# Altium schematic sheet 03_PAB.SchDoc(03_PAB)
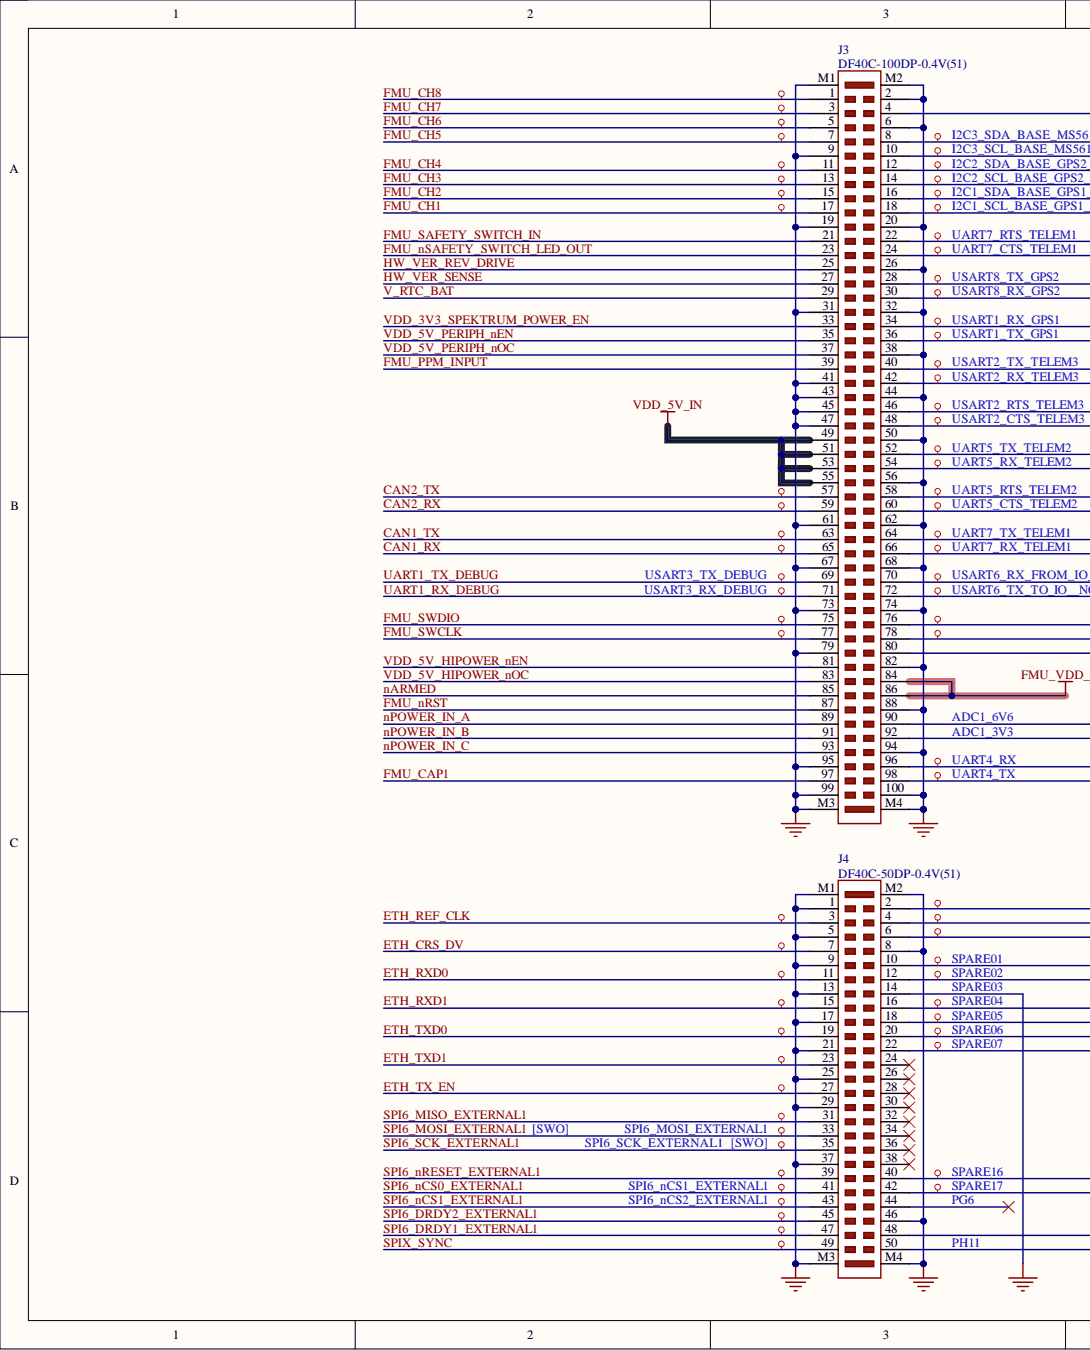
[diagram p0: 03_PAB.SchDoc, left]
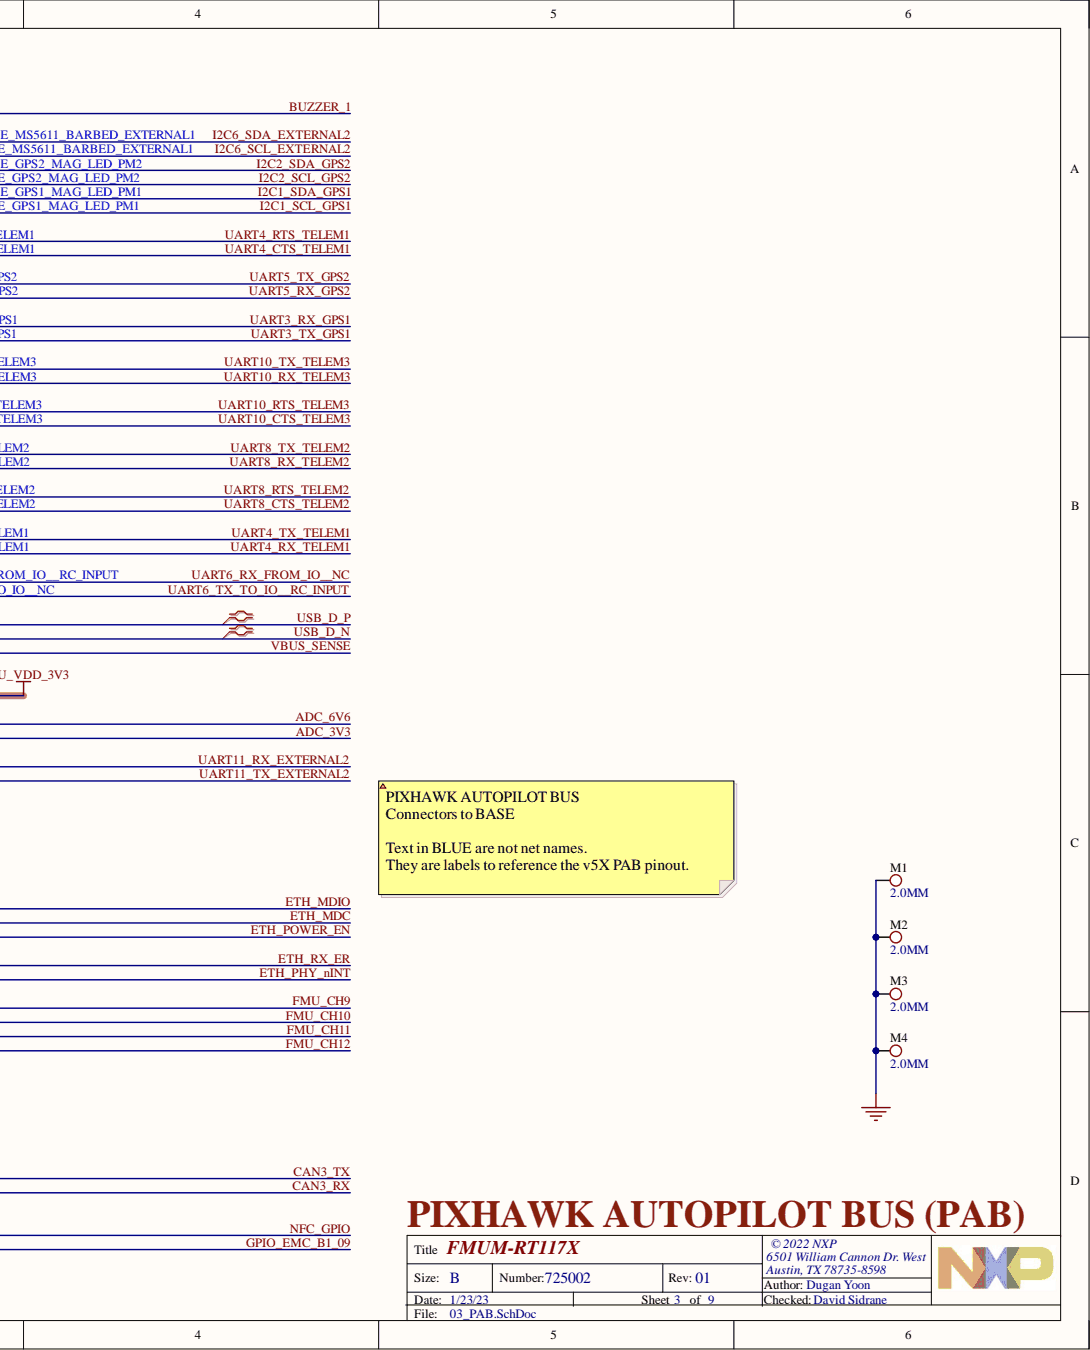
[diagram p1: 03_PAB.SchDoc, right]

## Parts
J3  Manufacturer Part Number: DF40C-100DP-0.4V(51)  [HIROSE_DF40C-100DP-0_4V51]  (hidden comment: CONN HDR 100POS 0.4MM SMD GOLD)
J4  Manufacturer Part Number: DF40C-50DP-0.4V(51)  [HIROSE_DF40C-50DP-0-4V51]  (hidden comment: CONN HDR 50POS 0.4MM SMD GOLD)
M1  ID: 2.0MM  [MOUNT_HOLE_2MM_3.6MM_8via_TH]  (hidden comment: MOUNT HOLE 2MM 3.6MM 8VIAS TH)  (no BOM)
M2  ID: 2.0MM  [MOUNT_HOLE_2MM_3.6MM_8via_TH]  (hidden comment: MOUNT HOLE 2MM 3.6MM 8VIAS TH)  (no BOM)
M3  ID: 2.0MM  [MOUNT_HOLE_2MM_3.6MM_8via_TH]  (hidden comment: MOUNT HOLE 2MM 3.6MM 8VIAS TH)  (no BOM)
M4  ID: 2.0MM  [MOUNT_HOLE_2MM_3.6MM_8via_TH]  (hidden comment: MOUNT HOLE 2MM 3.6MM 8VIAS TH)  (no BOM)

## Nets
FMU_VDD_3V3: J3.84 J3.86
GND: J3.2 J3.6 J3.9 J3.19 J3.20 J3.26 J3.31 J3.32 J3.38 J3.41 J3.43 J3.44 J3.45 J3.47 J3.50 J3.56 J3.61 J3.62 J3.67 J3.68 J3.73 J3.74 J3.79 J3.82 J3.88 J3.94 J3.95 J3.99 J3.100 J3.M1 J3.M2 J3.M3 J3.M4 J4.1 J4.5 J4.8 J4.9 J4.13 J4.14 J4.17 J4.21 J4.25 J4.29 J4.37 J4.46 J4.M1 J4.M2 J4.M3 J4.M4 M1.1 M2.1 M3.1 M4.1
VDD_5V_IN: J3.49 J3.51 J3.53 J3.55
ADC_3V3: J3.92
ADC_6V6: J3.90
BUZZER_1: J3.4
CAN1_RX: J3.65
CAN1_TX: J3.63
CAN2_RX: J3.59
CAN2_TX: J3.57
CAN3_RX: J4.42
CAN3_TX: J4.40
ETH_CRS_DV: J4.7
ETH_MDC: J4.4
ETH_MDIO: J4.2
ETH_PHY_nINT: J4.12
ETH_POWER_EN: J4.6
ETH_REF_CLK: J4.3
ETH_RX_ER: J4.10
ETH_RXD0: J4.11
ETH_RXD1: J4.15
ETH_TX_EN: J4.27
ETH_TXD0: J4.19
ETH_TXD1: J4.23
FMU_CAP1: J3.97
FMU_CH1: J3.17
FMU_CH2: J3.15
FMU_CH3: J3.13
FMU_CH4: J3.11
FMU_CH5: J3.7
FMU_CH6: J3.5
FMU_CH7: J3.3
FMU_CH8: J3.1
FMU_CH9: J4.16
FMU_CH10: J4.18
FMU_CH11: J4.20
FMU_CH12: J4.22
FMU_nRST: J3.87
FMU_nSAFETY_SWITCH_LED_OUT: J3.23
FMU_PPM_INPUT: J3.39
FMU_SAFETY_SWITCH_IN: J3.21
FMU_SWCLK: J3.77
FMU_SWDIO: J3.75
GPIO_EMC_B1_09: J4.50
HW_VER_REV_DRIVE: J3.25
HW_VER_SENSE: J3.27
I2C1_SCL_GPS1: J3.18
I2C1_SDA_GPS1: J3.16
I2C2_SCL_GPS2: J3.14
I2C2_SDA_GPS2: J3.12
I2C6_SCL_EXTERNAL2: J3.10
I2C6_SDA_EXTERNAL2: J3.8
nARMED: J3.85
NFC_GPIO: J4.48
nPOWER_IN_A: J3.89
nPOWER_IN_B: J3.91
nPOWER_IN_C: J3.93
SPI6_DRDY1_EXTERNAL1: J4.47
SPI6_DRDY2_EXTERNAL1: J4.45
SPI6_MISO_EXTERNAL1: J4.31
SPI6_MOSI_EXTERNAL1: J4.33
SPI6_nCS0_EXTERNAL1: J4.41
SPI6_nCS1_EXTERNAL1: J4.43
SPI6_nRESET_EXTERNAL1: J4.39
SPI6_SCK_EXTERNAL1: J4.35
SPIX_SYNC: J4.49
UART1_RX_DEBUG: J3.71
UART1_TX_DEBUG: J3.69
UART3_RX_GPS1: J3.34
UART3_TX_GPS1: J3.36
UART4_CTS_TELEM1: J3.24
UART4_RTS_TELEM1: J3.22
UART4_RX_TELEM1: J3.66
UART4_TX_TELEM1: J3.64
UART5_RX_GPS2: J3.30
UART5_TX_GPS2: J3.28
UART6_RX_FROM_IO__NC: J3.70
UART6_TX_TO_IO__RC_INPUT: J3.72
UART8_CTS_TELEM2: J3.60
UART8_RTS_TELEM2: J3.58
UART8_RX_TELEM2: J3.54
UART8_TX_TELEM2: J3.52
UART10_CTS_TELEM3: J3.48
UART10_RTS_TELEM3: J3.46
UART10_RX_TELEM3: J3.42
UART10_TX_TELEM3: J3.40
UART11_RX_EXTERNAL2: J3.96
UART11_TX_EXTERNAL2: J3.98
USB_D_N: J3.78
USB_D_P: J3.76
V_RTC_BAT: J3.29
VBUS_SENSE: J3.80
VDD_3V3_SPEKTRUM_POWER_EN: J3.33
VDD_5V_HIPOWER_nEN: J3.81
VDD_5V_HIPOWER_nOC: J3.83
VDD_5V_PERIPH_nEN: J3.35
VDD_5V_PERIPH_nOC: J3.37

Not connected: J4 24 26 28 30 32 34 36 38 44

## Library data of the parts
J3 = CONN_HDR_2X50_PLUG_0.4MM_SMD: Manufacturer: Hirose Electric Co Ltd; Number of Positions: 100; Pitch: 0.016" (0.40mm); System: Connector; Category: Connectors, Interconnects; Component Kind: Standard; ComponentLink1Description: https://www.digikey.com/product-detail/en/hirose-electric-co-ltd/DF40C-100DP-0.4V-51/H11614CT-ND/1969494; ComponentLink1URL: https://www.digikey.com/product-detail/en/hirose-electric-co-ltd/DF40C-100DP-0.4V-51/H11614CT-ND/1969494; Description: CONN HDR 100POS 0.4MM SMD GOLD; Mounting Type: Surface Mount; Number of Rows: 2; Operating Temperature: -65°C ~ 85°C; Packaging: Cut Tape (CT); Part Status: Active; Pricing 1: 1=1.96 (USD); Stock 1: 27593; Supplier 1: Digi-Key; Supplier Part Number 1: H11614CT-ND; Library Name: NscDG_Integrated_Library.SchLib
J4 = CONN_HDR_2X25_PLUG_0.4MM_SMD: Manufacturer: Hirose Electric Co Ltd; Number of Positions: 50; Pitch: 0.016" (0.40mm); System: Connector; Category: Connectors, Interconnects; Component Kind: Standard; ComponentLink1Description: https://www.digikey.com/products/en/connectors-interconnects/rectangular-connectors-arrays-edge-type-mezzanine-board-to-board/308?k=DF40C-50DP-0.4V51&k=&pkeyword=DF40C-50DP-0.4V51&pv7=2&sf=0&quantity=&ColumnSort=0&page=1&pageSize=25; ComponentLink1URL: https://www.digikey.com/products/en/connectors-interconnects/rectangular-connectors-arrays-edge-type-mezzanine-board-to-board/308?k=DF40C-50DP-0.4V51&k=&pkeyword=DF40C-50DP-0.4V51&pv7=2&sf=0&quantity=&ColumnSort=0&page=1&pageSize=25; Description: CONN HDR 50POS 0.4MM SMD GOLD; Mounting Type: Surface Mount; Number of Rows: 2; Operating Temperature: -65°C ~ 85°C; Packaging: Cut Tape (CT); Part Status: Active; Pricing 1: 1=1.12, 10=0.982, 25=0.924, 50=0.8856, 100=0.847, 250=0.77, 500=0.693, 1000=0.616 (USD); Stock 1: 57993; Supplier 1: Digi-Key; Supplier Part Number 1: H11626CT-ND; Library Name: NscDG_Integrated_Library.SchLib
M1-M4 = MOUNT_HOLE_2MM_3.6MM_8VIAS_TH: CATEGORY: Mechanical; Description: MOUNT HOLE 2MM 3.6MM 8VIAS TH; Library Name: NscDG_Integrated_Library.SchLib
